# Altium schematic sheet BMB_Connectors.SchDoc(BMB_Connectors)
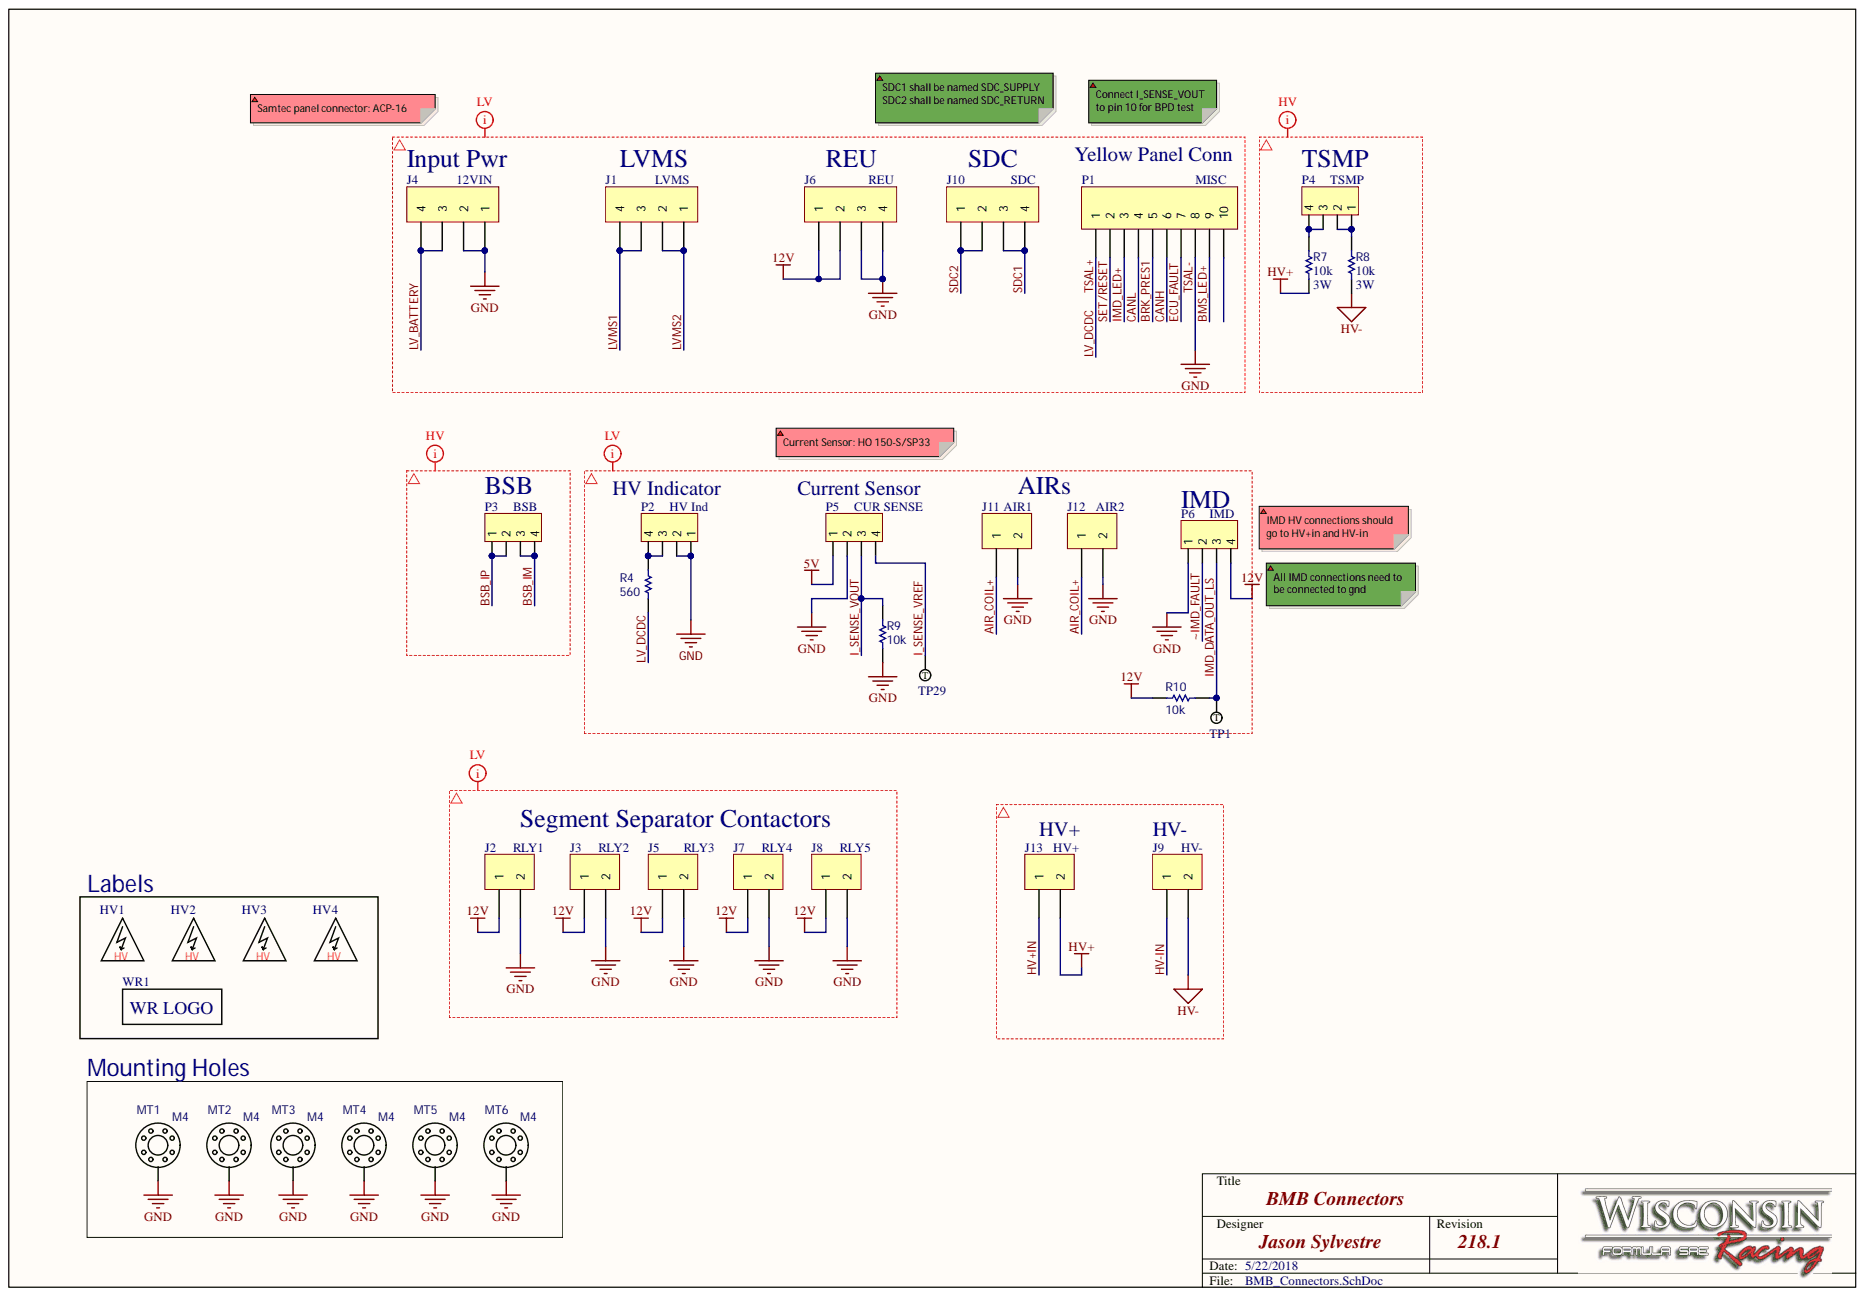
[diagram p0: BMB_Connectors.SchDoc]

## Parts
HV1  HV label  [HV Sticker]  (value not drawn)
HV2  HV label  [HV Sticker]  (value not drawn)
HV3  HV label  [HV Sticker]  (value not drawn)
HV4  HV label  [HV Sticker]  (value not drawn)
J1  LVMS  [IPBT-104-H1-T-S-RA-K]
J2  RLY1  [IPBT-102-H1-T-S-RA]
J3  RLY2  [IPBT-102-H1-T-S-RA]
J4  12VIN  [IPBT-104-H1-T-S-RA-K]
J5  RLY3  [IPBT-102-H1-T-S-RA]
J6  REU  [IPBT-104-H1-T-S-RA-K]
J7  RLY4  [IPBT-102-H1-T-S-RA]
J8  RLY5  [IPBT-102-H1-T-S-RA]
J9  HV-  [IPBT-102-H1-T-S-RA]
J10  SDC  [IPBT-104-H1-T-S-RA-K]
J11  AIR1  [IPBT-102-H1-T-S-RA]
J12  AIR2  [IPBT-102-H1-T-S-RA]
J13  HV+  [IPBT-102-H1-T-S-RA]
MT1  M4  [Mounting Hole M4]
MT2  M4  [Mounting Hole M4]
MT3  M4  [Mounting Hole M4]
MT4  M4  [Mounting Hole M4]
MT5  M4  [Mounting Hole M4]
MT6  M4  [Mounting Hole M4]
P1  MISC  [T2M-105-01-L-D-RA-WT]
P2  HV Ind  [T2M-102-01-L-D-RA-WT]
P3  BSB  [T2M-102-01-L-D-RA-WT]
P4  TSMP  [T2M-102-01-L-D-RA-WT]
P5  CUR SENSE  [T2M-102-01-L-D-RA-WT]
P6  IMD  [T2M-102-01-L-D-RA-WT]
R4  Res1  [RESC3216N]  (value not drawn)
R7  Resistance: 10k  Power Rating: 3W  [RESC6432AN]  (hidden comment: Res)
R8  Resistance: 10k  Power Rating: 3W  [RESC6432AN]  (hidden comment: Res)
R9  Resistance (Ohms): 10k  [RESC1608N]  (hidden comment: Res1)
R10  Resistance (Ohms): 10k  [RESC1608N]  (hidden comment: Res1)
TP1  Test Point  (value not drawn)
TP29  Test Point  (value not drawn)
WR1  WR_logo  [WR_Logo]  (value not drawn)

## Nets
5V: P5.1
12V: J2.1 J3.1 J5.1 J6.1 J6.2 J7.1 J8.1 P6.4 R10.2
HV+: J13.2 R7.1
HV-: J9.2 R8.1
AIR_COIL+: J11.1 J12.1
BMS_LED+: P1.9
BRK_PRES1: P1.5
BSB_IM: P3.3 P3.4
BSB_IP: P3.1 P3.2
CANH: P1.6
CANL: P1.4
ECU_FAULT: P1.7
HV+IN: J13.1
HV-IN: J9.1
I_SENSE_VOUT: P5.3 R9.2
I_SENSE_VREF: P5.4 TP29.1
IMD_DATA_OUT_LS: P6.3 R10.1 TP1.1
IMD_LED+: P1.3
LV_BATTERY: J4.3 J4.4
LV_DCDC: P1.1 R4.1
LVMS1: J1.3 J1.4
LVMS2: J1.1 J1.2
SDC1: J10.3 J10.4
SDC2: J10.1 J10.2
SET/RESET: P1.2
TSAL-: J2.2 J3.2 J4.1 J4.2 J5.2 J6.3 J6.4 J7.2 J8.2 J11.2 J12.2 MT1.1 MT2.1 MT3.1 MT4.1 MT5.1 MT6.1 P1.8 P2.1 P2.2 P5.2 P6.1 R9.1
~IMD_FAULT: P6.2
NetP2_3: P2.3 P2.4 R4.2
NetP4_1: P4.1 P4.2 R8.2
NetP4_3: P4.3 P4.4 R7.2
N00023:
N00024:
N00025:
N00026:
N00027:

Not connected: P1 10

## Library data of the parts
J1 J4 J6 J10 = IPBT-104-H1-T-S-RA: .165" Power Mate® Isolated Power Terminal Strip; Manufacturer: Samtec; Supplier Part Number 1: SAM9561-ND; Supplier 1: Digi-Key; Stock 1: 345; Pricing 1: 1=1.94, 10=1.757, 100=1.5057, 500=1.2548, 1000=1.0755, 5000=0.9536, 10000=0.9321 (USD); Manufacturer Part Number: IPBT-104-H1-T-S-RA-K; Library Name: Formula_Library.SchLib; Component Kind: Standard
J2 J3 J5 J7-J9 J11-J13 = IPBT-102-H1-T-S-RA: .165" Power Mate® Isolated Power Terminal Strip; Manufacturer Part Number: IPBT-102-H1-T-S-RA-K; Manufacturer: Samtec; Pitch: 4.19mm; Number of Contacts: 2; Gender: Male; Termination: Solder; Supplier Part Number 1: IPBT-102-H1-T-S-RA-K; Supplier 1: Arrow; Stock 1: 970; Radiation Hardening: No; Pricing 1: 1=1.3247, 10=1.1995, 25=1.1214, 50=1.0093, 100=0.9083, 250=0.8687, 500=0.865, 1000=0.8624, 2500=0.8581 (USD); Packaging: Rail/Tube; Orientation: Right Angle; Mount: Through Hole; Library Name: Formula_Library.SchLib; ComponentLink1URL: https://datasheet.ciiva.com/29939/ipbt-29939644.pdf; ComponentLink1Description: https://datasheet.ciiva.com/29939/ipbt-29939644.pdf; Component Kind: Standard
MT1-MT6 = M4 Mounting Hole: Library Name: Formula_Library.SchLib; Component Kind: Standard
P1 = Header 10: Header, 10-Pin; Manufacturer: Samtec; Signal Integrity: Connector; Manufacturer Part Number: MISC; Library Name: Miscellaneous Connectors.IntLib; Component Kind: Standard
P2-P6 = T2M connector 4: Manufacturer Part Number: T2M-102-01-L-D-SM-WT; Manufacturer: Samtec; Library Name: Formula_Library.SchLib; Component Kind: Standard
R4 = Res1: Resistor; Manufacturer Part Number 1: ERJ-8GEYJ561V; Manufacturer 1: Panasonic Electronic Components; Tolerance: ±5%; Temperature Coefficient: ±200ppm/°C; Resistance (Ohms): 560; Power (Watts): 0.25W, 1/4W; Description 1: RES SMD 560 OHM 5% 1/4W 1206; Category 1: Resistors; Supplier Part Number 1: P560ECT-ND; Supplier Device Package: 1206; Supplier 1: Digi-Key; Stock 1: 117541; Size / Dimension: 0.126" L x 0.063" W (3.20mm x 1.60mm); Simulation: RESISTOR; Signal Integrity: Res; RoHS: RoHS Compliant; Pricing 1: 1=0.1, 50=0.0212, 100=0.0156, 250=0.01188, 500=0.0095, 1000=0.007 (USD); Packaging: Cut Tape (CT); Package / Case: 1206 (3216 Metric); Operating Temperature: -55°C ~ 155°C; Number of Terminations: 2; Library Name: Miscellaneous Devices.IntLib; Height: 0.028" (0.70mm); Features: Automotive AEC-Q200; Composition: Thick Film; ComponentLink1URL: http://industrial.panasonic.com/www-cgi/jvcr13pz.cgi?E+PZ+3+AOA0001+ERJ8GEYJ561V+7+WW; ComponentLink1Description: http://industrial.panasonic.com/www-cgi/jvcr13pz.cgi?E+PZ+3+AOA0001+ERJ8GEYJ561V+7+WW; Component Kind: Standard
R7 R8 = Res1: Resistor; Manufacturer Part Number: 352210KFT; Manufacturer: TE Connectivity CGS; Voltage Rating: 250V; Tolerance: 1%; Temperature Coefficient: 100ppm/°C; Number of Resistors: 1; Termination: Solder; Supplier Part Number 1: A121120CT-ND; Supplier 1: Digi-Key; Stock 1: 2000; Simulation: RESISTOR; Signal Integrity: Res; REACH SVHC: Unknown; Pricing 1: 1=0.85, 10=0.738, 100=0.5143, 1000=0.3019 (USD); Packaging: Tape and Reel; Number of Terminations: 2; Mount: Surface Mount; Min Operating Temperature: -55°C; Max Operating Temperature: 155°C; Library Name: Miscellaneous Devices.IntLib; Length: 6.35mm; Height: 1.1mm; Depth: 3.2mm; Composition: Thick Film,Power resistor; ComponentLink2URL: http://uk.rs-online.com/webdocs/142b/0900766b8142bb21.pdf; ComponentLink2Description: http://uk.rs-online.com/webdocs/142b/0900766b8142bb21.pdf; ComponentLink1URL: http://www.farnell.com/datasheets/2007092.pdf; ComponentLink1Description: http://www.farnell.com/datasheets/2007092.pdf; Component Kind: Standard; Case Code (Metric): 6432; Case Code (Imperial): 2512; Case/Package: 2512
R9 R10 = Res1: Resistor; Manufacturer Part Number 1: ERJ-3GEYJ103V; Manufacturer 1: Panasonic Electronic Components; Tolerance: ±5%; Temperature Coefficient: ±200ppm/°C; Power (Watts): 0.1W, 1/10W; Description 1: RES SMD 10K OHM 5% 1/10W 0603; Category 1: Resistors; Supplier Part Number 1: P10KGCT-ND; Supplier Device Package: 0603; Supplier 1: Digi-Key; Stock 1: 3676462; Size / Dimension: 0.063" L x 0.031" W (1.60mm x 0.80mm); Simulation: RESISTOR; Signal Integrity: Res; RoHS: RoHS Compliant; Pricing 1: 1=0.1, 50=0.0096, 100=0.0071, 250=0.00544, 500=0.00436, 1000=0.00321 (USD); Packaging: Cut Tape (CT); Package / Case: 0603 (1608 Metric); Operating Temperature: -55°C ~ 155°C; Number of Terminations: 2; Library Name: Miscellaneous Devices.IntLib; Height: 0.022" (0.55mm); Features: Automotive AEC-Q200; Composition: Thick Film; ComponentLink1URL: http://industrial.panasonic.com/www-cgi/jvcr13pz.cgi?E+PZ+3+AOA0001+ERJ3GEYJ103V+7+WW; ComponentLink1Description: http://industrial.panasonic.com/www-cgi/jvcr13pz.cgi?E+PZ+3+AOA0001+ERJ3GEYJ103V+7+WW; Component Kind: Standard
TP1 TP29 = Test Point: Material - Insulation: No Insulation; Type: PC Test Point; Supplier Part Number 1: A106145CT-ND; Supplier 1: Digi-Key; Stock 1: 136646; Size / Dimension: 0.063" x 0.031" x 0.045" (1.60mm x 0.80mm x 1.15mm); RoHS: RoHS Compliant; Pricing 1: 1=0.22, 10=0.206, 25=0.1756, 50=0.1536, 100=0.1426, 250=0.12616, 500=0.12068, 1000=0.09874 (USD); Plating: -; Part Status: Active; Packaging: Cut Tape (CT); Mounting Type: Surface Mount; Material - Body: -; Library Name: Formula_Library.SchLib; Description: Test Point; ComponentLink1URL: http://www.te.com/commerce/DocumentDelivery/DDEController?Action=srchrtrv&DocNm=1773266&DocType=DS&DocLang=English; ComponentLink1Description: http://www.te.com/commerce/DocumentDelivery/DDEController?Action=srchrtrv&DocNm=1773266&DocType=DS&DocLang=English; Component Kind: Standard; Color: Natural; Category: Test and Measurement
HV1-HV4 = HV label: Library Name: Formula_Library.SchLib; Component Kind: Standard
WR1 = WR_logo: Library Name: Formula_Library.SchLib; Component Kind: Standard
